# Altium schematic sheet TRINKIEROCKS P6.SchDoc
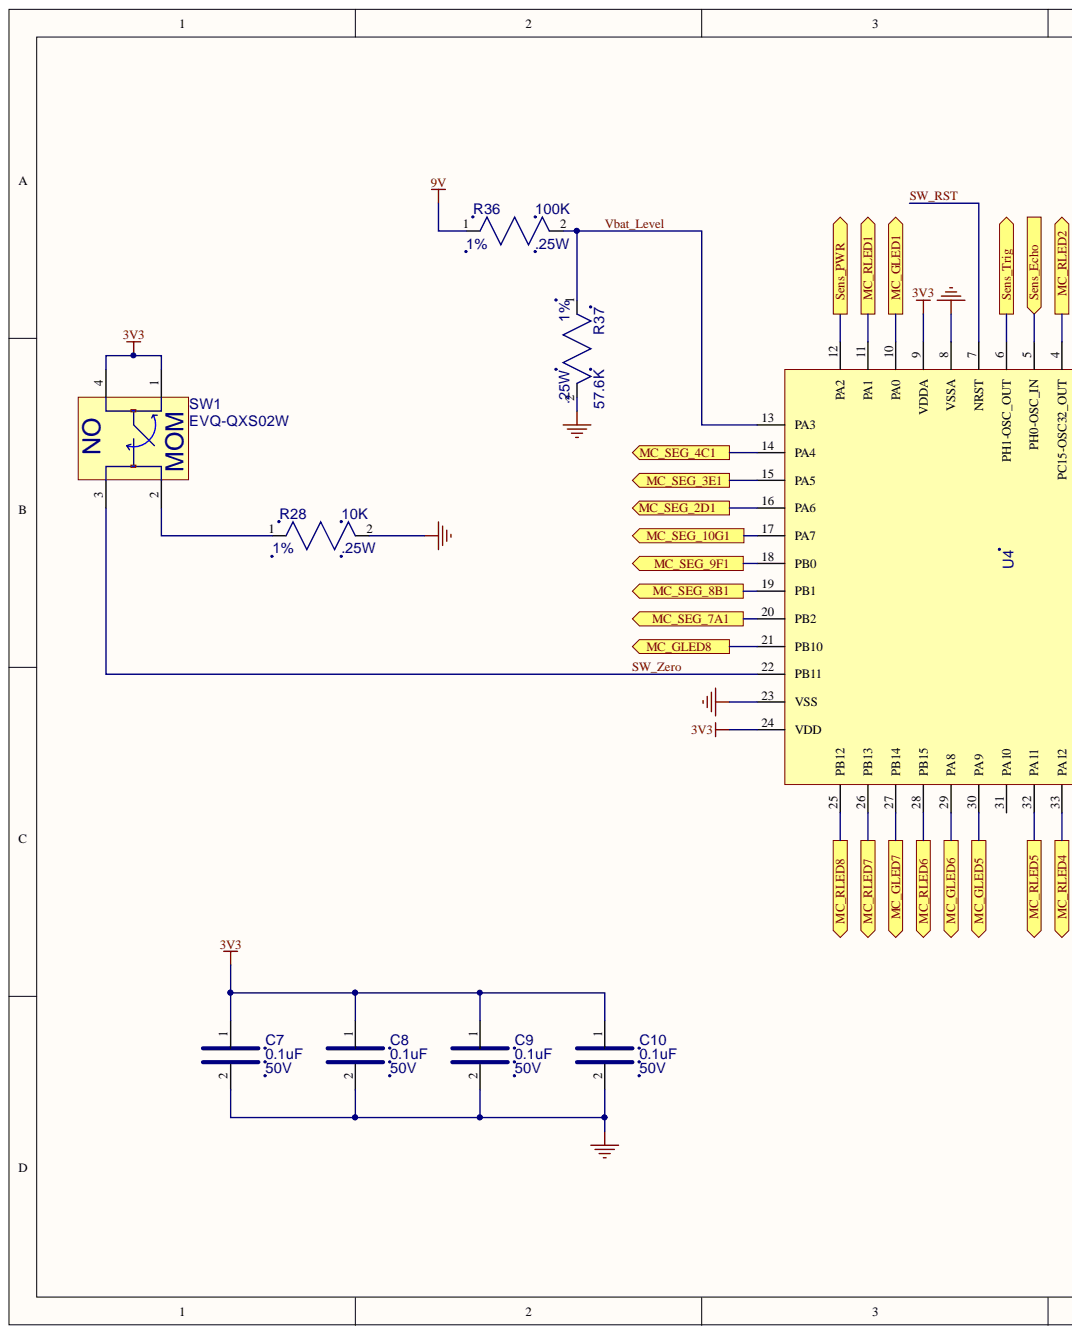
[diagram p0: TRINKIEROCKS P6.SchDoc, left]
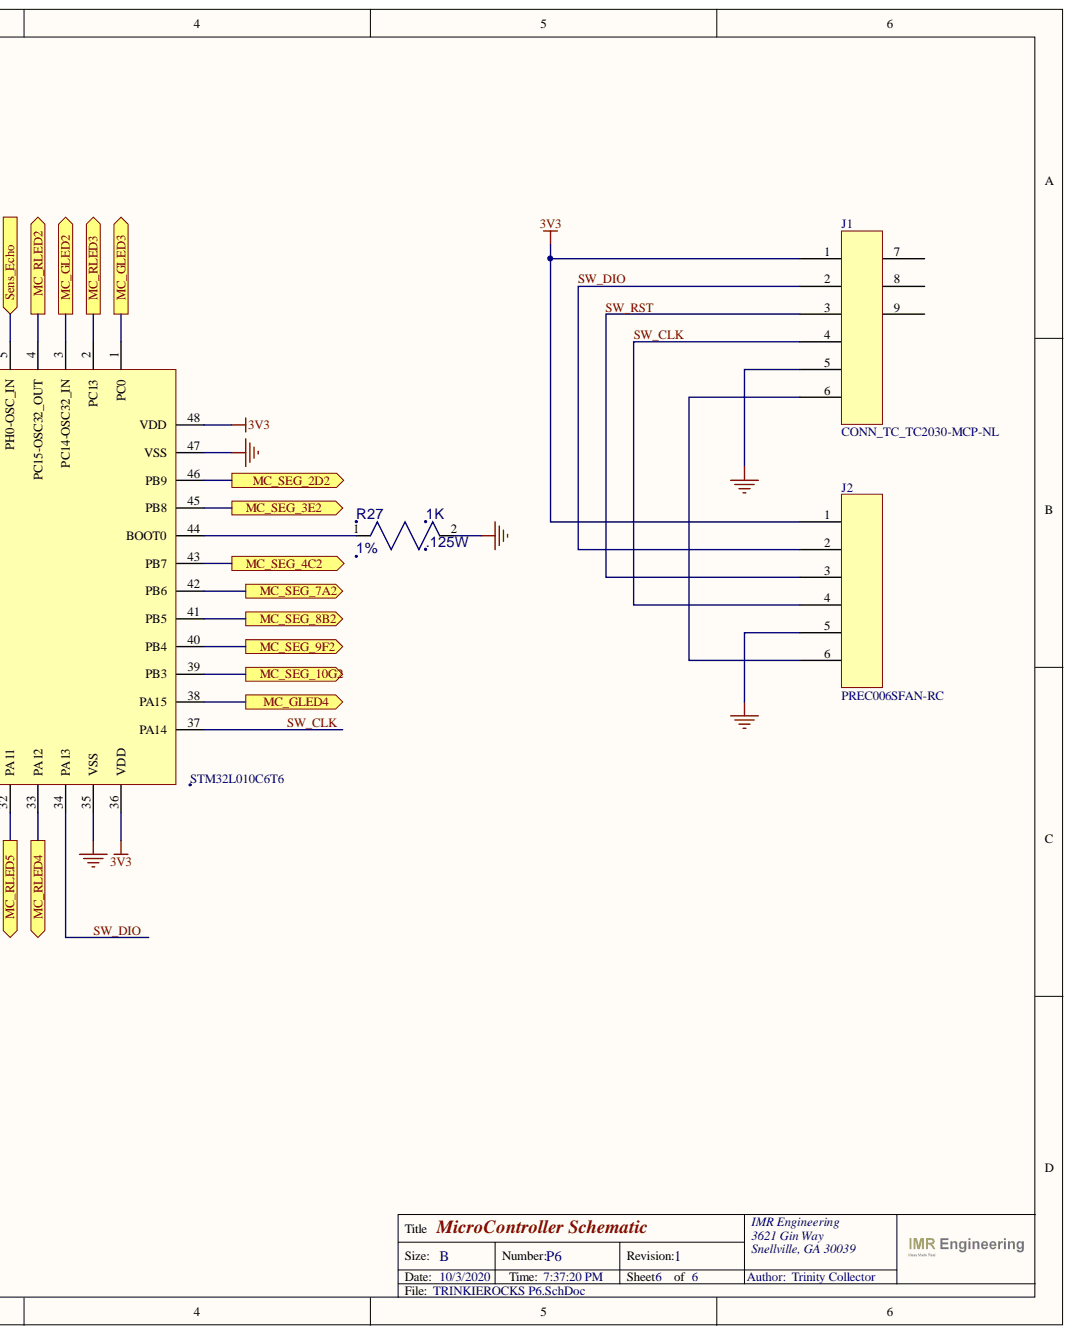
[diagram p1: TRINKIEROCKS P6.SchDoc, right]

## Parts
C7  value 0.1uF  VOLTAGE: 50V  [CAP_0805]  (hidden comment: 08055C104KAT2A)
C8  value 0.1uF  VOLTAGE: 50V  [CAP_0805]  (hidden comment: 08055C104KAT2A)
C9  value 0.1uF  VOLTAGE: 50V  [CAP_0805]  (hidden comment: 08055C104KAT2A)
C10  value 0.1uF  VOLTAGE: 50V  [CAP_0805]  (hidden comment: 08055C104KAT2A)
J1  CONN_TC_TC2030-MCP-NL  [TC2030-MCP-NL-]  (value not drawn)  (no BOM)
J2  PREC006SFAN-RC  [HDR_1X6_100MILL]
R27  value 1K  POWER: .125W  TOLERANCE: 1%  [RES_0805]  (hidden comment: RC0805FR-071KL)
R28  value 10K  POWER: .25W  TOLERANCE: 1%  [RES_0805]  (hidden comment: SG73S2ATTD1002F)
R36  value 100K  POWER: .25W  TOLERANCE: 1%  [RES_0805]  (hidden comment: RK73H2ATTD1003F)
R37  value 57.6K  POWER: .25W  TOLERANCE: 1%  [RES_0805]  (hidden comment: RK73H2ATTD5762F)
SW1  SW1  value EVQ-QXS02W  MFG_PN: EVQ-QXS02W  [PANASONIC_EVQQX]
U4  STM32L010C6T6  [QFP50P900X900X160-48N]

## Ports
MC_GLED1, MC_GLED2, MC_GLED3, MC_GLED4, MC_GLED5, MC_GLED6, MC_GLED7, MC_GLED8, MC_RLED1, MC_RLED2, MC_RLED3, MC_RLED4, MC_RLED5, MC_RLED6, MC_RLED7, MC_RLED8, MC_SEG_2D1, MC_SEG_2D2, MC_SEG_3E1, MC_SEG_3E2, MC_SEG_4C1, MC_SEG_4C2, MC_SEG_7A1, MC_SEG_7A2, MC_SEG_8B1, MC_SEG_8B2, MC_SEG_9F1, MC_SEG_9F2, MC_SEG_10G1, MC_SEG_10G2, SENS_ECHO, SENS_PWR, SENS_TRIG

## Nets
3V3: C7.1 C8.1 C9.1 C10.1 J1.1 J2.1 SW1.1 SW1.4(NO) U4.9(VDDA) U4.24(VDD) U4.36(VDD) U4.48(VDD)
9V: R36.1
GND: C7.2 C8.2 C9.2 C10.2 J1.5 J2.5 R27.2 R28.2 R37.2 U4.8(VSSA) U4.23(VSS) U4.35(VSS) U4.47(VSS)
MC_GLED1: U4.10(PA0) ; port MC_GLED1
MC_GLED2: U4.3(PC14-OSC32_IN) ; port MC_GLED2
MC_GLED3: U4.1(PC0) ; port MC_GLED3
MC_GLED4: U4.38(PA15) ; port MC_GLED4
MC_GLED5: U4.30(PA9) ; port MC_GLED5
MC_GLED6: U4.29(PA8) ; port MC_GLED6
MC_GLED7: U4.27(PB14) ; port MC_GLED7
MC_GLED8: U4.21(PB10) ; port MC_GLED8
MC_RLED1: U4.11(PA1) ; port MC_RLED1
MC_RLED2: U4.4(PC15-OSC32_OUT) ; port MC_RLED2
MC_RLED3: U4.2(PC13) ; port MC_RLED3
MC_RLED4: U4.33(PA12) ; port MC_RLED4
MC_RLED5: U4.32(PA11) ; port MC_RLED5
MC_RLED6: U4.28(PB15) ; port MC_RLED6
MC_RLED7: U4.26(PB13) ; port MC_RLED7
MC_RLED8: U4.25(PB12) ; port MC_RLED8
MC_SEG_2D1: U4.16(PA6) ; port MC_SEG_2D1
MC_SEG_2D2: U4.46(PB9) ; port MC_SEG_2D2
MC_SEG_3E1: U4.15(PA5) ; port MC_SEG_3E1
MC_SEG_3E2: U4.45(PB8) ; port MC_SEG_3E2
MC_SEG_4C1: U4.14(PA4) ; port MC_SEG_4C1
MC_SEG_4C2: U4.43(PB7) ; port MC_SEG_4C2
MC_SEG_7A1: U4.20(PB2) ; port MC_SEG_7A1
MC_SEG_7A2: U4.42(PB6) ; port MC_SEG_7A2
MC_SEG_8B1: U4.19(PB1) ; port MC_SEG_8B1
MC_SEG_8B2: U4.41(PB5) ; port MC_SEG_8B2
MC_SEG_9F1: U4.18(PB0) ; port MC_SEG_9F1
MC_SEG_9F2: U4.40(PB4) ; port MC_SEG_9F2
MC_SEG_10G1: U4.17(PA7) ; port MC_SEG_10G1
MC_SEG_10G2: U4.39(PB3) ; port MC_SEG_10G2
SW_CLK: J1.4 J2.4 U4.37(PA14)
SW_DIO: J1.2 J2.2 U4.34(PA13)
SW_RST: J1.3 J2.3 U4.7(NRST)
SW_ZERO: SW1.3 U4.22(PB11)
VBAT_LEVEL: R36.2 R37.1 U4.13(PA3)
NetJ1_6: J1.6 J2.6
NetR27_1: R27.1 U4.44(BOOT0)
NetR28_1: R28.1 SW1.2
NetU4_5: U4.5(PH0-OSC_IN) ; port SENS_ECHO
NetU4_6: U4.6(PH1-OSC_OUT) ; port SENS_TRIG
NetU4_12: U4.12(PA2) ; port SENS_PWR

Not connected: J1 7 8 9; U4 31(PA10)

## Library data of the parts
C7-C10 = CAP_0.1UF_50V_CER: CAP CER 0.1UF 50V X7R 0805; MFG: AVX; TOLERANCE: 10%; Case/Package: 0805; Component Kind: Standard; MFG_PN: 08055C104KAT2A; Supplier 1: Digi-Key; Supplier Part Number 1: 478-1395-1-ND; Library Name: Trinkie.SchLib
J1 = CONN_TC_TC2030-MCP-NL: CABLE TAG-CONNECT IN-CIRCUIT; MFG: Tag-Connect LLC; MFG_PN: TC2030-MCP-NL; Supplier 1: Digi-Key; Supplier Part Number 1: TC2030-MCP-NLTC-ND; Library Name: Trinkie.SchLib
J2 = CONN_6P_SINGLE_ROW: CONN HEADER VERT 6POS 2.54MM; MFG: Sullins Connector Solutions; Case/Package: 6P_2.54MM; Component Kind: Standard; MFG_PN: PREC006SFAN-RC; Supplier 1: DigiKey; Supplier Part Number 1: S1212EC-06-ND; Library Name: Trinkie.SchLib
R27 = RES_1K_0805_1/8W_1%: RES SMD 1K OHM 1% 1/8W 0805; MFG: Yageo; Case/Package: 0805; Component Kind: Standard; MFG_PN: RC0805FR-071KL; Supplier 1: Digi-Key; Supplier Part Number 1: 311-1.00KCRCT-ND; Library Name: Trinkie.SchLib
R28 = RES_10K_0805_1/4W_1%: RES SMD 10K OHM 1% 1/4W 0805; MFG: KOA Speer Electronics, Inc.; Case/Package: 0805; Component Kind: Standard; MFG_PN: SG73S2ATTD1002F; Supplier 1: Digi-Key; Supplier Part Number 1: 2019-SG73S2ATTD1002FCT-ND; Library Name: Trinkie.SchLib
R36 = RES_100K_0805_1/4W_1%: RES SMD 100K OHM 1% 1/4W 0805; MFG: KOA Speer Electronics, Inc.; Case/Package: 0805; Component Kind: Standard; MFG_PN: RK73H2ATTD1003F; Supplier 1: Digi-Key; Supplier Part Number 1: 2019-RK73H2ATTD1003FCT-ND; Library Name: Trinkie.SchLib
R37 = RES_57.6K_1/4W_1%: RES SMD 100K OHM 1% 1/4W 0805; MFG: KOA Speer Electronics, Inc.; Case/Package: 0805; Component Kind: Standard; MFG_PN: RK73H2ATTD5762F; Supplier 1: Digi-Key; Supplier Part Number 1: 2019-RK73H2ATTD5762FCT-ND; Library Name: Trinkie.SchLib
SW1 = SW1: SWITCH, MOM, NO, SM; MFG: PANASONIC; Code_JEDEC: DO-204-AH; Component Kind: Standard; ComponentLink1Description: Manufacturer Link; ComponentLink1URL: http://www.fairchildsemi.com/; ComponentLink2Description: Datasheet; ComponentLink2URL: http://www.fairchildsemi.com/ds/1N%2F1N4148.pdf; DatasheetDocument: 1997; LatestRevisionDate: 17-Jul-2002; LatestRevisionNote: Re-released for DXP Platform.; PackageReference: DO-35; Published: 8-Jun-2000; Supplier 1: Digi-Key; Supplier Part Number 1: P13612SCT-ND; Library Name: Trinkie.SchLib; Publisher: Altium Limited
U4 = IC_MICRO_32BIT_32K/8K: IC, MICRO CONTROLLER, ARM CORTEX-M0+, 32K/8K 32MHz; MFG: ST Microelectronics; Case/Package: 48-LQFP; Component Kind: Standard; MFG_PN: STM32L010C6T6; Supplier 1: Digi-Key; Supplier Part Number 1: 497-19662-ND; VALUE: STM32L010C6T6; Library Name: Trinkie.SchLib
